# Altium schematic sheet IFRP.SchDoc(IFRP)
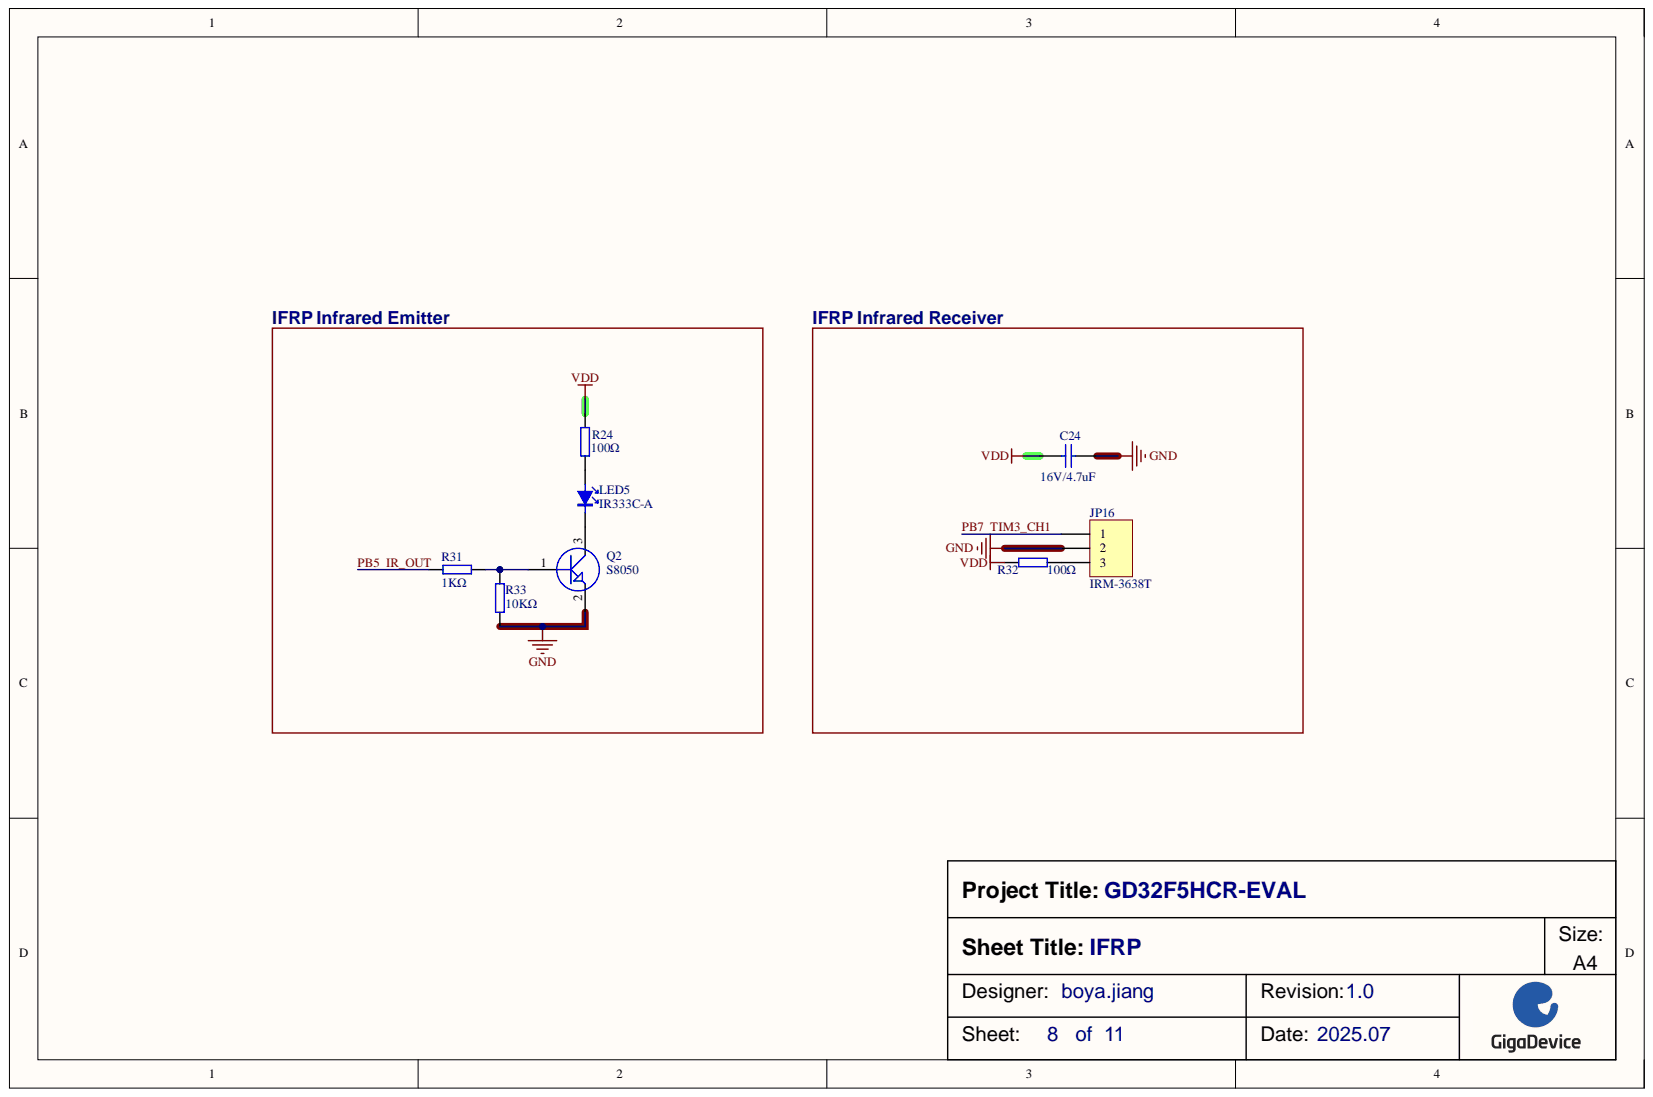
[diagram p0: IFRP.SchDoc]

## Parts
C24  16V/4.7uF  [0603-C]
JP16  IRM-3638T  [TSOP348]
LED5  IR333C-A  [D0.1L]
Q2  S8050  [SOT-23]
R24  100Ω  [0603-R]
R31  1KΩ  [0603-R]
R32  100Ω  [0603-R]
R33  10KΩ  [0603-R]

## Nets
GND: C24.1 JP16.2 Q2.2 R33.1
VDD: C24.2 R24.2 R32.1
IR_OUT: R31.1
PB7: JP16.1
NetJP16_3: JP16.3 R32.2
NetLED5_1: LED5.1 R24.1
NetLED5_2: LED5.2 Q2.3
NetQ2_1: Q2.1 R31.2 R33.2

## Library data of the parts
C24 = 10V/4.7uF: 表贴电容, X7R; Component Kind: Standard; Library Name: KLTC-电容.SchLib
JP16 = HEADER 3: 单排2.54接口, 红外线接收头; Component Kind: Standard; Library Name: KLTC-按键及接插件.SchLib
LED5 = KD-03144R: 直插3mm红色发光二极管; Component Kind: Standard; Library Name: KLTC-二三极管.SchLib
Q2 = 9014(PMBS3904): 表贴三极管; Component Kind: Standard; Library Name: KLTC-二三极管.SchLib
R24 = 470Ω: 表贴电阻; Component Kind: Standard; Library Name: KLTC-电阻.SchLib
R31-R33 = 1KΩ: 表贴电阻; Component Kind: Standard; Library Name: KLTC-电阻.SchLib
